# Altium schematic sheet iWatch-screen.SchDoc
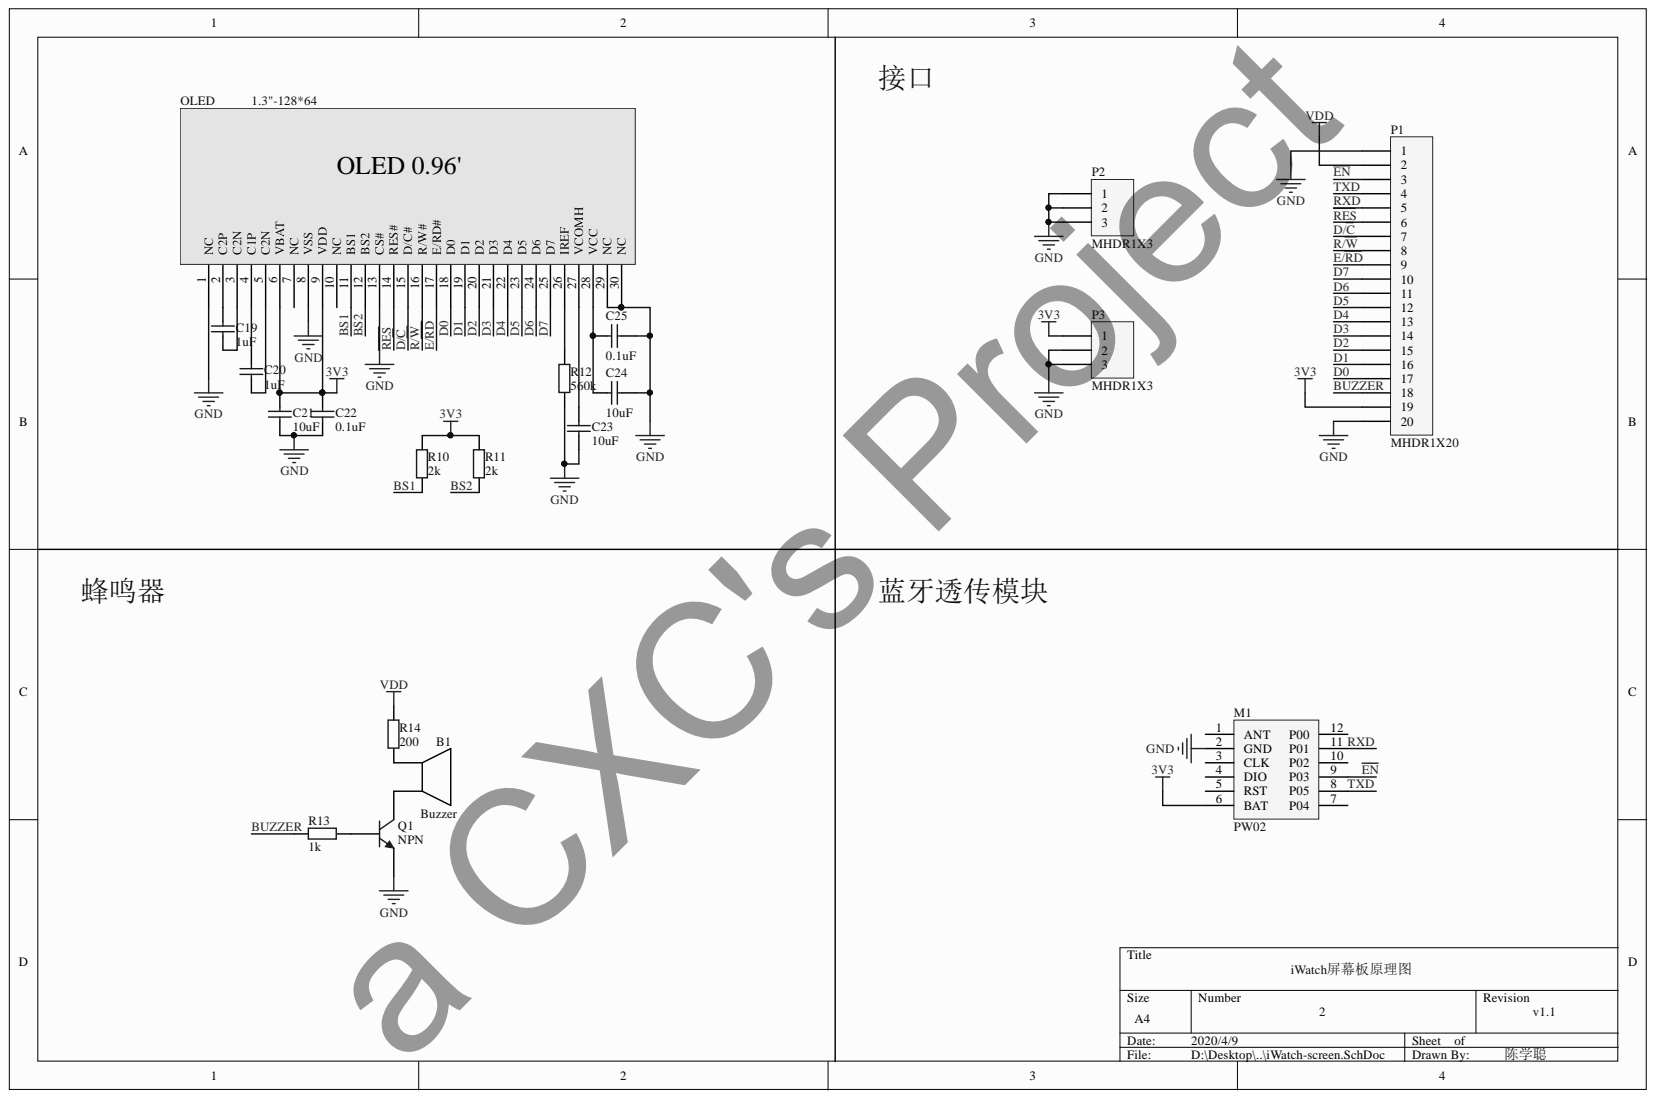
[diagram p0: iWatch-screen.SchDoc]

## Parts
B1  Buzzer  [蜂鸣器5020 - 嵌入]
C19  1uF  [C-0603-M]
C20  1uF  [C-0603-M]
C21  10uF  [C-0603-M]
C22  0.1uF  [C-0603-M]
C23  10uF  [C-0603-M]
C24  10uF  [C-0603-M]
C25  0.1uF  [C-0603-M]
M1  PW02  [PW02]
OLED  1.3"-128*64  [OLED-1.3-90]
P1  MHDR1X20  [MHDR1X20]
P2  MHDR1X3  [MHDR1X3]
P3  MHDR1X3  [MHDR1X3]
Q1  NPN  [SOT-23(SOT-23-3)]
R10  2k  [R-0603-M]
R11  2k  [R-0603-M]
R12  560k  [R-0603-M]
R13  1k  [R-0603-M]
R14  200  [R-0603-M]

## Nets
3V3: C21.1 C22.1 M1.6 OLED.6 OLED.9 P1.19 P3.1 R10.2 R11.2
GND: C21.2 C22.2 C23.2 C24.1 C25.1 M1.2 OLED.1 OLED.8 OLED.13 OLED.29 OLED.30 P1.1 P1.20 P2.1 P2.2 P2.3 P3.2 P3.3 Q1.2 R12.2
VDD: P1.2 R14.2
BS1: OLED.11 R10.1
BS2: OLED.12 R11.1
BUZZER: P1.18 R13.1
D0: OLED.18 P1.17
D1: OLED.19 P1.16
D2: OLED.20 P1.15
D3: OLED.21 P1.14
D4: OLED.22 P1.13
D5: OLED.23 P1.12
D6: OLED.24 P1.11
D7: OLED.25 P1.10
D/~{C}: OLED.15 P1.7
E/~{RD}: OLED.17 P1.9
~{EN}: M1.9 P1.3
R/~{W}: OLED.16 P1.8
~{RES}: OLED.14 P1.6
RXD: M1.11 P1.5
TXD: M1.8 P1.4
NetB1_1: B1.1 R14.1
NetB1_2: B1.2 Q1.3
NetC19_1: C19.1 OLED.2
NetC19_2: C19.2 OLED.3
NetC20_1: C20.1 OLED.4
NetC20_2: C20.2 OLED.5
NetC23_1: C23.1 OLED.27
NetC24_2: C24.2 C25.2 OLED.28
NetOLED_26: OLED.26 R12.1
NetQ1_1: Q1.1 R13.2

Not connected: M1 1 3 4 5 7 10 12; OLED 7 10

## Library data of the parts
B1 = Buzzer: 蜂鸣器; Component Kind: Standard; Library Name: cxc's integrated library.IntLib
C19-C25 = Cap: 陶瓷电容; Component Kind: Standard; LatestRevisionDate: 17-Jul-2002; LatestRevisionNote: Re-released for DXP Platform.; PackageDescription: Radial Cap, Thru-Hole; 2 Leads; 0.3 in Pin Spacing; PackageReference: RAD-0.3; Published: 8-Jun-2000; Signal Integrity: Cap; Simulation: CAP; Library Name: cxc's integrated library.IntLib; Publisher: Altium Limited
M1 = PW02: 蓝牙模块; Component Kind: Standard; Library Name: cxc's integrated library.IntLib
OLED = OLED-1.3: Component Kind: Standard; Library Name: cxc's integrated library.IntLib
P1 = MHDR1X20: Header, 20-Pin; Component Kind: Standard; LatestRevisionDate: 17-Jul-2002; LatestRevisionNote: Re-released for DXP Platform.; Signal Integrity: Connector; Library Name: cxc's integrated library.IntLib; Publisher: Altium Limited
P2 P3 = MHDR1X3: Header, 3-Pin; Component Kind: Standard; LatestRevisionDate: 17-Jul-2002; LatestRevisionNote: Re-released for DXP Platform.; Signal Integrity: Connector; Library Name: cxc's integrated library.IntLib; Publisher: Altium Limited
Q1 = NPN: NPN Bipolar Transistor; Code_JEDEC: TO-226-AA; Component Kind: Standard; LatestRevisionDate: 17-Jul-2002; LatestRevisionNote: Re-released for DXP Platform.; PackageDescription: TO, Flat Index; 3 In-Line, Axial Leads; Body Dia. 4.8mm; Leads 0.55 x 0.51 mm (max); PackageReference: TO-226-AA; Published: 8-Jun-2000; Signal Integrity: NPN; Simulation: NPN; Library Name: cxc's integrated library.IntLib; Publisher: Altium Limited
R10-R14 = Resistor: Component Kind: Standard; Description: Resistor; LatestRevisionDate: 17-Jul-2002; LatestRevisionNote: Re-released for DXP Platform.; PackageDescription: Axial Device, Thru-Hole; 2 Leads; 0.4 in Pin Spacing; PackageReference: AXIAL-0.4; Published: 8-Jun-2000; Signal Integrity: Res; Simulation: RESISTOR; Library Name: cxc's integrated library.IntLib; Publisher: Altium Limited
